# Altium schematic sheet PI_CAN_Interface_v3.SchDoc
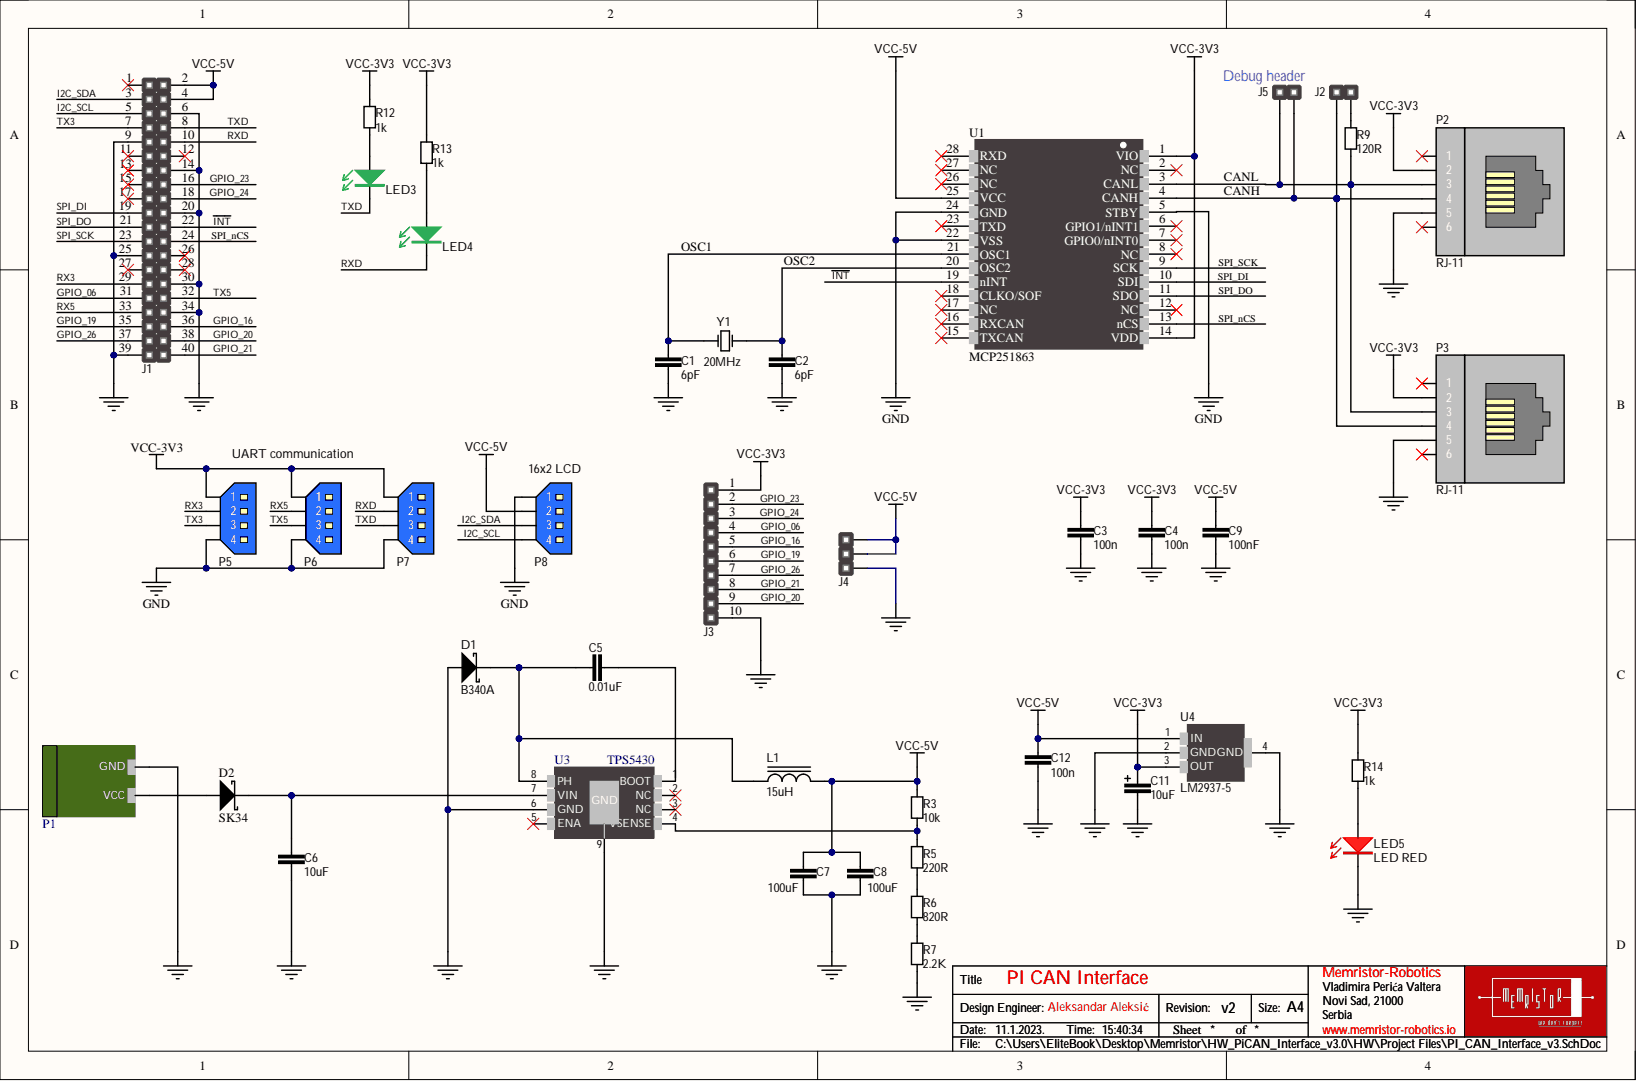
[diagram p0: PI_CAN_Interface_v3.SchDoc]

## Parts
C1  6pF  [CER_0603]
C2  6pF  [CER_0603]
C3  100n  [CER_0603]
C4  100n  [CER_0603]
C5  0.01uF  [CER_0603]
C6  10uF  [CER_1210]
C7  100uF  [CER_1210]
C8  100uF  [CER_1210]
C9  100nF  [CER_0805]
C11  10uF  [TANT_1210]
C12  100n  [CER_0603]
D1  B340A  [DO214AC]
D2  SK34  [DO214AC]
J1  00000005  [HM2X20]  (value not drawn)
J2  (no value)  [HM1x2]
J3  00000006  [HM1X10]  (value not drawn)
J4  (no value)  [HM1X3]
J5  (no value)  [HM1x2]
L1  15uH  [INDUCTOR_SS1260-103MLD]
LED3  LED GREEN  [LED_GREEN_0805]  (value not drawn)
LED4  LED GREEN  [LED_GREEN_0805]  (value not drawn)
LED5  LED RED  Family: LED  [LED_RED_0805]
P1  (no value)  [PWR_Con]
P2  RJ-11  [RJ11-6N-B]
P3  RJ-11  [RJ11-6N-B]
P5  TE CONNECTIVITY_4PIN_MALE  [TE CONNECTIVITY_4PIN_MALE]  (value not drawn)
P6  TE CONNECTIVITY_4PIN_MALE  [TE CONNECTIVITY_4PIN_MALE]  (value not drawn)
P7  TE CONNECTIVITY_4PIN_MALE  [TE CONNECTIVITY_4PIN_MALE]  (value not drawn)
P8  TE CONNECTIVITY_4PIN_MALE  [TE CONNECTIVITY_4PIN_MALE]  (value not drawn)
R3  10k  [RESC2013X38N-0805-NOOVERLAY]
R5  220R  [RESC2013X38N-0805-NOOVERLAY]
R6  820R  [RESC2013X38N-0805-NOOVERLAY]
R7  2.2K  [RESC2013X38N-0805-NOOVERLAY]
R9  120R  [RESC2013X38N-0805-NOOVERLAY]
R12  1k  [RESC2013X38N-0805-NOOVERLAY]
R13  1k  [RESC2013X38N-0805-NOOVERLAY]
R14  1k  [RESC2013X38N-0805-NOOVERLAY]
U1  MCP251863  [SSOP-28]
U3  TPS5430  [HSOP-8]
U4  LM2937-5  [SOT223]
Y1  20MHz  [OSCILATOR]

## Nets
GND: C1.1 C2.1 C3.1 C4.1 C6.1 C7.1 C8.1 C9.1 C11.2 C12.1 D1.2 J1.6 J1.9 J1.14 J1.20 J1.25 J1.30 J1.34 J1.39 J3.10 J4.3 LED5.1 P1.1 P2.5 P3.5 P5.4 P6.4 P7.4 P8.1 R7.1 U1.5(STBY) U1.22(VSS) U1.24(GND) U3.6(GND) U3.9 U4.2(GND) U4.4(GND)
VCC-3V3: C3.2 C4.2 C11.1 J3.1 P2.2 P3.2 P5.1 P6.1 P7.1 R12.2 R13.2 R14.2 U1.1(VIO) U1.14(VDD) U4.3(OUT)
VCC-5V: C7.2 C8.2 C9.2 C12.2 J1.2 J1.4 J4.1 J4.2 L1.2 P8.2 R3.2 U1.25(VCC) U4.1(IN)
CANH: J2.1 J5.2 P2.4 P3.4 U1.4(CANH)
CANL: J5.1 P2.3 P3.3 R9.1 U1.3(CANL)
GPIO_06: J1.31 J3.4
GPIO_16: J1.36 J3.5
GPIO_19: J1.35 J3.6
GPIO_20: J1.38 J3.9
GPIO_21: J1.40 J3.8
GPIO_23: J1.16 J3.2
GPIO_24: J1.18 J3.3
GPIO_26: J1.37 J3.7
I2C_SCL: J1.5 P8.4
I2C_SDA: J1.3 P8.3
~{INT}: J1.22 U1.19(nINT)
OSC1: C1.2 U1.21(OSC1) Y1.1
OSC2: C2.2 U1.20(OSC2) Y1.2
RX3: J1.29 P5.2
RX5: J1.33 P6.2
RXD: J1.10 LED4.1 P7.2
SPI_DI: J1.19 U1.10(SDI)
SPI_DO: J1.21 U1.11(SDO)
SPI_nCS: J1.24 U1.13(nCS)
SPI_SCK: J1.23 U1.9(SCK)
TX3: J1.7 P5.3
TX5: J1.32 P6.3
TXD: J1.8 LED3.1 P7.3
NetC5_1: C5.1 D1.1 L1.1 U3.8(PH)
NetC5_2: C5.2 U3.1(BOOT)
NetC6_2: C6.2 D2.1 U3.7(VIN)
NetD2_2: D2.2 P1.2
NetJ2_2: J2.2 R9.2
NetLED3_2: LED3.2 R12.1
NetLED4_2: LED4.2 R13.1
NetLED5_2: LED5.2 R14.1
NetR3_1: R3.1 R5.2 U3.4(VSENSE)
NetR5_1: R5.1 R6.2
NetR6_1: R6.1 R7.2

Not connected: J1 1 11 12 13 15 17 26 27 28; P2 1 6; P3 1 6; U1 2(NC) 6(GPIO1/nINT1) 7(GPIO0/nINT0) 8(NC) 12(NC) 15(TXCAN) 16(RXCAN) 17(NC) 18(CLKO/SOF) 23(TXD) 26(NC) 27(NC) 28(RXD); U3 2(NC) 3(NC) 5(ENA)

## Library data of the parts
C1 C2 = 00002200: CAP CER 20p 0805; Capacitance: 6pF; Component Kind: Standard; Library Name: Capacitors.IntLib
C3-C5 C12 = 06032105: CAP CER 100n 10V X5R 10% 0603; Component Kind: Standard; Library Name: Capacitors.IntLib
  C3 C4 C12: Capacitance: 100n
  C5: Capacitance: 0.01uF
C6 = 00002225: CAP CER 2.2u X7R 100V 20% 1210; Capacitance: 10uF; Component Kind: Standard; Library Name: Capacitors.IntLib
C7 C8 = 00002108: CAP CER 100u X5R 6.3V 10% 1210; Capacitance: 100uF; Component Kind: Standard; Library Name: Capacitors.IntLib
C9 = 00002105: CAP CER 100n 50V X7R 10% 0805; Capacitance: 100nF; Component Kind: Standard; Library Name: Capacitors.IntLib
C11 = 00001106: CAP TANT 10u; Component Kind: Standard; Library Name: Capacitors.SchLib; Value: 10uF
D1 = B360B: DIODE SCHOTTKY 60V 3A DO214AC; Manufacturer: Vishay Semiconductor Diodes Division; Assembly: SMD; Barcode: 0100000007488; id: 62; item_id: 1025; Recommended Rating: Silver; Speed: Fast Recovery =< 500ns, > 200mA (Io); Voltage  Forward: 550mV @ 3A; Category: Discrete Semiconductor Products; Component Kind: Standard; Current  Average Rectified: 3A; Datasheet URL: http://www.vishay.com/docs/88896/b330la.pdf; Diode Type: Schottky; Family: DIODE; Library Name: Discrete_Semiconductor.IntLib; Manufacturer Part Number: B340A; Package Case: DO-214AC, SMA; Part Type: Discrete Semiconductors; Voltage  DC Reverse: 60V
D2 = SK34: DIODE SCHOTTKY 40V 3A DO214AC; Manufacturer: Vishay Semiconductor Diodes Division; Assembly: SMD; Barcode: 0100000007488; id: 62; item_id: 1025; Recommended Rating: Silver; Speed: Fast Recovery =< 500ns, > 200mA (Io); Voltage  Forward: 550mV @ 3A; Category: Discrete Semiconductor Products; Component Kind: Standard; Current  Average Rectified: 3A; Datasheet URL: http://www.vishay.com/docs/88896/b330la.pdf; Diode Type: Schottky; Family: DIODE; Library Name: Discrete_Semiconductor.IntLib; Manufacturer Part Number: SK34; Package Case: DO-214AC, SMA; Part Type: Discrete Semiconductors; Voltage  DC Reverse: 40V
J2 = 00000003: Component Kind: Standard; Library Name: Electro_Mechanical.IntLib
J4 = HEADER_1x3: Component Kind: Standard; Library Name: Electro_Mechanical.IntLib
J5 = HEADER_1x2: Component Kind: Standard; Library Name: Electro_Mechanical.IntLib
L1 = IND_10uH: FIXED IND 10uH 3A; Manufacturer: Coilcraft; Manufacturer Part Number: XAL7030-103MEB; Assembly: SMD; DC Resistance: 45mOhm; Tolerance: 20%; Category: Inductors, Coils, Chokes; Component Kind: Standard; Current Rating: 3A; Datasheet URL: http://www.mouser.com/ds/2/597/MSS7348-1076571.pdf; Family: INDUCTOR; Inductance: 15uH; Library Name: Discrete_Semiconductor.IntLib; Package Case: 8x8mm; Part Type: Inductor, Transf, Filter, Ferrite; Product Page Mouser: https://eu.mouser.com/ProductDetail/Coilcraft/MSS7348-103MEB/?qs=sGAEpiMZZMsg%252by3WlYCkU%252bbmGPYv72aIHZue6QQJkp0%3d
LED3 LED4 = LED_GREEN 0805: LED GREEN CLEAR 0805 SMD; Manufacturer: Kingbright; Manufacturer Part Number: APT2012SGC; Barcode: 2911010024338; id: 28; item_id: 3342; Lens Color: Colorless; Recommended Rating: Platinum; Voltage Forward: 2.2V; Wavelength: 568nm; Assembly: SMD; Category: Optoelectronics; Color: Green; Component Kind: Standard; Datasheet URL: http://www.kingbrightusa.com/images/catalog/SPEC/APT2012SGC.pdf; Family: LED; Lens Transparency: Clear; Library Name: Discrete_Semiconductor.IntLib; Package Case: 0805 (2012 Metric); Part Type: Optoelectronics
LED5 = LED_RED_0805: LED RED 0805; Manufacturer: BRIGHT LED ELECTRONICS; Manufacturer Part Number: BL-HUB35A-AV-TRB; Assembly: SMD; Barcode: 2911010024321; id: 35; item_id: 3341; Recommended Rating: Platinum; Voltage Forward: 2.0 V; Color: Red; Component Kind: Standard; Library Name: Discrete_Semiconductor.IntLib; Package Case: 0805 (2012 Metric); Part Type: Optoelectronics
P1 = 00000002: Component Kind: Standard; Library Name: Electro_Mechanical.IntLib
P2 P3 = 00000001: Component Kind: Standard; Library Name: Electro_Mechanical.IntLib; Manufacturer Part Number: RJ-11
P5-P8 = TE CONNECTIVITY_4PIN_MALE: BLUE_4PIN_CONECTOR; Manufacturer: TE CONNECTIVITY / AMP; Manufacturer Part Number 1: 281695-3; Order Code 1: 1205983; Component Kind: Standard; Library Name: Electro_Mechanical.IntLib; Price 1: £0.403; Supplier 1: uk.farnell.com
R3 = 00005102: RES SMD 51 kOhm 1/8W 0805; Power: 0.125W, 1/8W; Assembly: SMD; Barcode: 00005102; Component Kind: Standard; Library Name: Resistors.IntLib; Resistance: 10k
R5-R7 = 00009101: RES SMD 9.1 kOhm 1/8W 0805; Power: 0.125W, 1/8W; Assembly: SMD; Barcode: 00009101; Component Kind: Standard; Library Name: Resistors.IntLib
  R5: Resistance: 220R
  R6: Resistance: 820R
  R7: Resistance: 2.2K
R9 = 000001200: RES SMD 120 Ohm 1/8W 0805; Component Kind: Standard; Library Name: Resistors.SchLib; Resistance: 120R
R12-R14 = 00001001: RES SMD 1 kOhm 1/8W 0805; Barcode: 00009101; Power: 0.125W, 1/8W; Assembly: SMD; Component Kind: Standard; Library Name: Resistors.SchLib; Resistance: 1k
U4 = 00000007: IC REG LINEAR 5V 400MA SOT223-4; Component Kind: Standard; Datasheet Link: http://www.ti.com/lit/ds/symlink/lm2937.pdf; Library Name: Integrated_circuits.SchLib; Manufacturer Part Number: LM2937-5
Y1 = XtalSmd: Crystal Oscillator; Order Code: Q16.000 MHz HC-49SM SMD; Component Kind: Standard; LatestRevisionDate: 17-Jul-2002; LatestRevisionNote: Re-released for DXP Platform.; Library Name: Discrete_Semiconductor.IntLib; PackageReference: R38; Published: 8-Jun-2000; Supplier: Comet.rs; Value: 20MHz; Publisher: Altium Limited
J1 = 00000005: Component Kind: Standard; Library Name: Electro_Mechanical.IntLib
J3 = 00000006: Component Kind: Standard; Library Name: Electro_Mechanical.IntLib
U1 = MCP251863: Component Kind: Standard; Library Name: Integrated_circuits.SchLib
U3 = TPS5430: Component Kind: Standard; Library Name: TPS5430.SchLib
